# Altium schematic sheet IPM_Driver.SchDoc
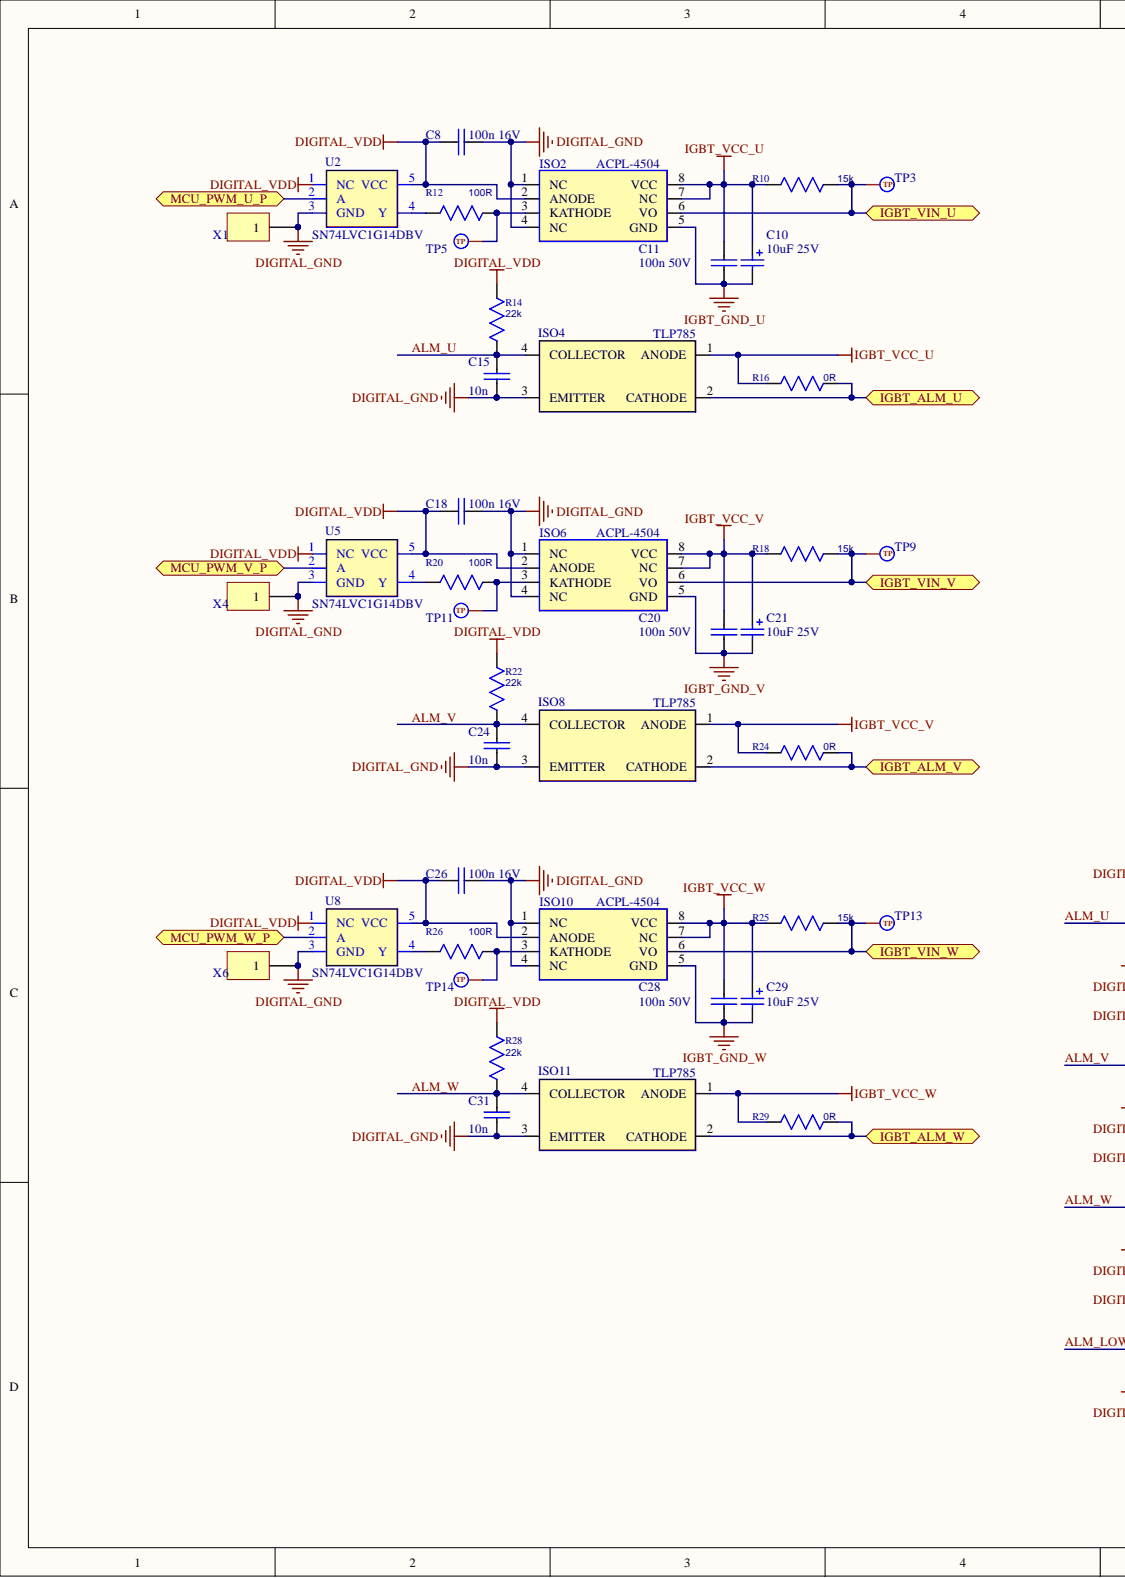
[diagram p0: IPM_Driver.SchDoc, left]
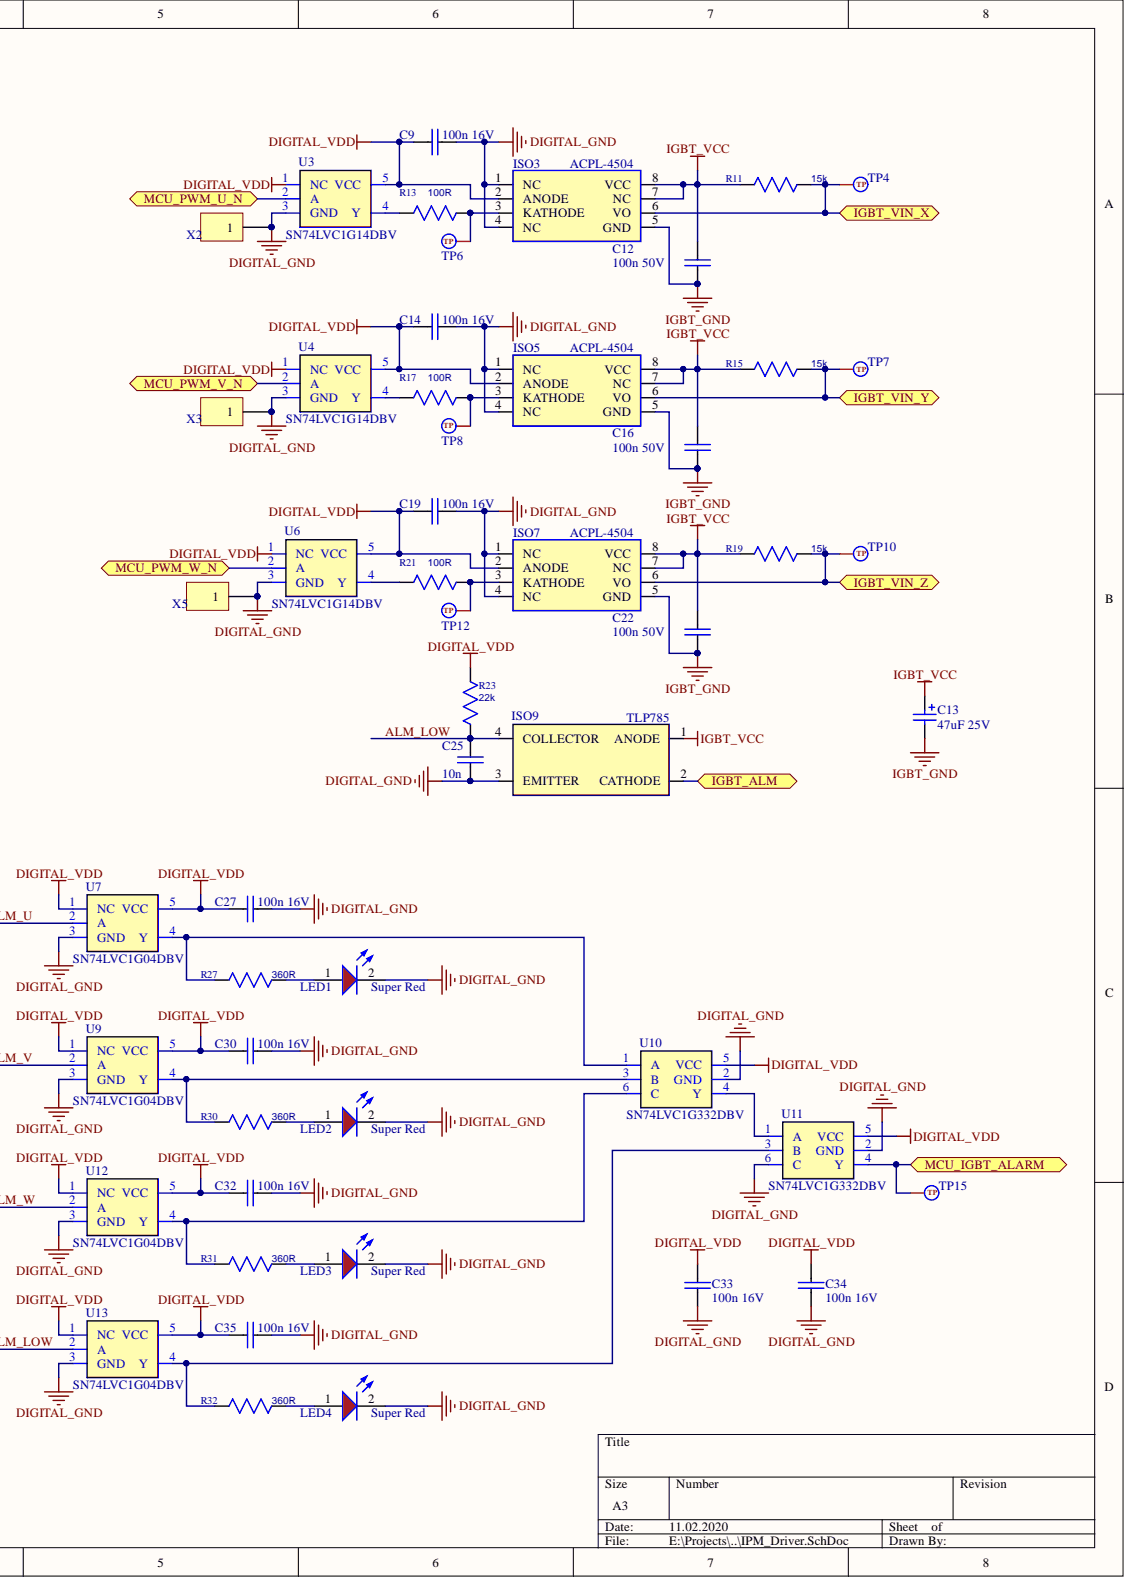
[diagram p1: IPM_Driver.SchDoc, right]

## Parts
C8  100n 16V  [C_0805]
C9  100n 16V  [C_0805]
C10  10uF 25V  [C]
C11  100n 50V  [C_0805]
C12  100n 50V  [C_0805]
C13  47uF 25V  [D]
C14  100n 16V  [C_0805]
C15  10n  [C_0805]
C16  100n 50V  [C_0805]
C18  100n 16V  [C_0805]
C19  100n 16V  [C_0805]
C20  100n 50V  [C_0805]
C21  10uF 25V  [C]
C22  100n 50V  [C_0805]
C24  10n  [C_0805]
C25  10n  [C_0805]
C26  100n 16V  [C_0805]
C27  100n 16V  [C_0805]
C28  100n 50V  [C_0805]
C29  10uF 25V  [C]
C30  100n 16V  [C_0805]
C31  10n  [C_0805]
C32  100n 16V  [C_0805]
C33  100n 16V  [C_0805]
C34  100n 16V  [C_0805]
C35  100n 16V  [C_0805]
ISO2  ACPL-4504  [DIP8-300]
ISO3  ACPL-4504  [DIP8-300]
ISO4  TLP785  [TLP785TP6]
ISO5  ACPL-4504  [DIP8-300]
ISO6  ACPL-4504  [DIP8-300]
ISO7  ACPL-4504  [DIP8-300]
ISO8  TLP785  [TLP785TP6]
ISO9  TLP785  [TLP785TP6]
ISO10  ACPL-4504  [DIP8-300]
ISO11  TLP785  [TLP785TP6]
LED1  Super Red  [LED_0805_Super Red]
LED2  Super Red  [LED_0805_Super Red]
LED3  Super Red  [LED_0805_Super Red]
LED4  Super Red  [LED_0805_Super Red]
R10  15k  [R_0805]
R11  15k  [R_0805]
R12  100R  [R_0805]
R13  100R  [R_0805]
R14  22k  [R_0805]
R15  15k  [R_0805]
R16  0R  [R_0805]
R17  100R  [R_0805]
R18  15k  [R_0805]
R19  15k  [R_0805]
R20  100R  [R_0805]
R21  100R  [R_0805]
R22  22k  [R_0805]
R23  22k  [R_0805]
R24  0R  [R_0805]
R25  15k  [R_0805]
R26  100R  [R_0805]
R27  360R  [R_0805]
R28  22k  [R_0805]
R29  0R  [R_0805]
R30  360R  [R_0805]
R31  360R  [R_0805]
R32  360R  [R_0805]
TP3  TEST_POINT  [TEST POINT D1.5]  (value not drawn)
TP4  TEST_POINT  [TEST POINT D1.5]  (value not drawn)
TP5  TEST_POINT  [TEST POINT D1.5]  (value not drawn)
TP6  TEST_POINT  [TEST POINT D1.5]  (value not drawn)
TP7  TEST_POINT  [TEST POINT D1.5]  (value not drawn)
TP8  TEST_POINT  [TEST POINT D1.5]  (value not drawn)
TP9  TEST_POINT  [TEST POINT D1.5]  (value not drawn)
TP10  TEST_POINT  [TEST POINT D1.5]  (value not drawn)
TP11  TEST_POINT  [TEST POINT D1.5]  (value not drawn)
TP12  TEST_POINT  [TEST POINT D1.5]  (value not drawn)
TP13  TEST_POINT  [TEST POINT D1.5]  (value not drawn)
TP14  TEST_POINT  [TEST POINT D1.5]  (value not drawn)
TP15  TEST_POINT  [TEST POINT D1.5]  (value not drawn)
U2  SN74LVC1G14DBV  [SOT23-5]
U3  SN74LVC1G14DBV  [SOT23-5]
U4  SN74LVC1G14DBV  [SOT23-5]
U5  SN74LVC1G14DBV  [SOT23-5]
U6  SN74LVC1G14DBV  [SOT23-5]
U7  SN74LVC1G04DBV  [SOT23-5]
U8  SN74LVC1G14DBV  [SOT23-5]
U9  SN74LVC1G04DBV  [SOT23-5]
U10  SN74LVC1G332DBV  [SOT23-6]
U11  SN74LVC1G332DBV  [SOT23-6]
U12  SN74LVC1G04DBV  [SOT23-5]
U13  SN74LVC1G04DBV  [SOT23-5]
X1  PLS-1  [PLS-1-3D]  (value not drawn)
X2  PLS-1  [PLS-1-3D]  (value not drawn)
X3  PLS-1  [PLS-1-3D]  (value not drawn)
X4  PLS-1  [PLS-1-3D]  (value not drawn)
X5  PLS-1  [PLS-1-3D]  (value not drawn)
X6  PLS-1  [PLS-1-3D]  (value not drawn)

## Ports
IGBT_ALM, IGBT_ALM_U, IGBT_ALM_V, IGBT_ALM_W, IGBT_VIN_U, IGBT_VIN_V, IGBT_VIN_W, IGBT_VIN_X, IGBT_VIN_Y, IGBT_VIN_Z, MCU_IGBT_ALARM, MCU_PWM_U_N, MCU_PWM_U_P, MCU_PWM_V_N, MCU_PWM_V_P, MCU_PWM_W_N, MCU_PWM_W_P

## Nets
DIGITAL_GND: C8.2 C9.2 C14.2 C15.2 C18.2 C19.2 C24.2 C25.2 C26.2 C27.2 C30.2 C31.2 C32.2 C33.1 C34.1 C35.2 ISO2.1(NC) ISO2.4(NC) ISO3.1(NC) ISO3.4(NC) ISO4.3(EMITTER) ISO5.1(NC) ISO5.4(NC) ISO6.1(NC) ISO6.4(NC) ISO7.1(NC) ISO7.4(NC) ISO8.3(EMITTER) ISO9.3(EMITTER) ISO10.1(NC) ISO10.4(NC) ISO11.3(EMITTER) LED1.2 LED2.2 LED3.2 LED4.2 U2.3(GND) U3.3(GND) U4.3(GND) U5.3(GND) U6.3(GND) U7.3(GND) U8.3(GND) U9.3(GND) U10.2(GND) U11.2(GND) U11.6(C) U12.3(GND) U13.3(GND) X1.1 X2.1 X3.1 X4.1 X5.1 X6.1
DIGITAL_VDD: C8.1 C9.1 C14.1 C18.1 C19.1 C26.1 C27.1 C30.1 C32.1 C33.2 C34.2 C35.1 ISO2.2(ANODE) ISO3.2(ANODE) ISO5.2(ANODE) ISO6.2(ANODE) ISO7.2(ANODE) ISO10.2(ANODE) R14.2 R22.2 R23.2 R28.2 U2.1(NC) U2.5(VCC) U3.1(NC) U3.5(VCC) U4.1(NC) U4.5(VCC) U5.1(NC) U5.5(VCC) U6.1(NC) U6.5(VCC) U7.1(NC) U7.5(VCC) U8.1(NC) U8.5(VCC) U9.1(NC) U9.5(VCC) U10.5(VCC) U11.5(VCC) U12.1(NC) U12.5(VCC) U13.1(NC) U13.5(VCC)
IGBT_GND: C12.2 C13.2 C16.2 C22.2 ISO3.5(GND) ISO5.5(GND) ISO7.5(GND)
IGBT_GND_U: C10.2 C11.2 ISO2.5(GND)
IGBT_GND_V: C20.2 C21.2 ISO6.5(GND)
IGBT_GND_W: C28.2 C29.2 ISO10.5(GND)
IGBT_VCC: C12.1 C13.1 C16.1 C22.1 ISO3.7(NC) ISO3.8(VCC) ISO5.7(NC) ISO5.8(VCC) ISO7.7(NC) ISO7.8(VCC) ISO9.1(ANODE) R11.1 R15.1 R19.1
IGBT_VCC_U: C10.1 C11.1 ISO2.7(NC) ISO2.8(VCC) ISO4.1(ANODE) R10.1 R16.2
IGBT_VCC_V: C20.1 C21.1 ISO6.7(NC) ISO6.8(VCC) ISO8.1(ANODE) R18.1 R24.2
IGBT_VCC_W: C28.1 C29.1 ISO10.7(NC) ISO10.8(VCC) ISO11.1(ANODE) R25.1 R29.2
ALM_LOW: C25.1 ISO9.4(COLLECTOR) R23.1 U13.2(A)
ALM_U: C15.1 ISO4.4(COLLECTOR) R14.1 U7.2(A)
ALM_V: C24.1 ISO8.4(COLLECTOR) R22.1 U9.2(A)
ALM_W: C31.1 ISO11.4(COLLECTOR) R28.1 U12.2(A)
IGBT_ALM: ISO9.2(CATHODE) ; port IGBT_ALM
IGBT_ALM_U: ISO4.2(CATHODE) R16.1 ; port IGBT_ALM_U
IGBT_ALM_V: ISO8.2(CATHODE) R24.1 ; port IGBT_ALM_V
IGBT_ALM_W: ISO11.2(CATHODE) R29.1 ; port IGBT_ALM_W
IGBT_VIN_U: ISO2.6(VO) R10.2 TP3.1 ; port IGBT_VIN_U
IGBT_VIN_V: ISO6.6(VO) R18.2 TP9.1 ; port IGBT_VIN_V
IGBT_VIN_W: ISO10.6(VO) R25.2 TP13.1 ; port IGBT_VIN_W
IGBT_VIN_X: ISO3.6(VO) R11.2 TP4.1 ; port IGBT_VIN_X
IGBT_VIN_Y: ISO5.6(VO) R15.2 TP7.1 ; port IGBT_VIN_Y
IGBT_VIN_Z: ISO7.6(VO) R19.2 TP10.1 ; port IGBT_VIN_Z
MCU_IGBT_ALARM: TP15.1 U11.4(Y) ; port MCU_IGBT_ALARM
MCU_PWM_U_N: U3.2(A) ; port MCU_PWM_U_N
MCU_PWM_U_P: U2.2(A) ; port MCU_PWM_U_P
MCU_PWM_V_N: U4.2(A) ; port MCU_PWM_V_N
MCU_PWM_V_P: U5.2(A) ; port MCU_PWM_V_P
MCU_PWM_W_N: U6.2(A) ; port MCU_PWM_W_N
MCU_PWM_W_P: U8.2(A) ; port MCU_PWM_W_P
NetISO2_3: ISO2.3(KATHODE) R12.2 TP5.1
NetISO3_3: ISO3.3(KATHODE) R13.2 TP6.1
NetISO5_3: ISO5.3(KATHODE) R17.2 TP8.1
NetISO6_3: ISO6.3(KATHODE) R20.2 TP11.1
NetISO7_3: ISO7.3(KATHODE) R21.2 TP12.1
NetISO10_3: ISO10.3(KATHODE) R26.2 TP14.1
NetLED1_1: LED1.1 R27.1
NetLED2_1: LED2.1 R30.1
NetLED3_1: LED3.1 R31.1
NetLED4_1: LED4.1 R32.1
NetR12_1: R12.1 U2.4(Y)
NetR13_1: R13.1 U3.4(Y)
NetR17_1: R17.1 U4.4(Y)
NetR20_1: R20.1 U5.4(Y)
NetR21_1: R21.1 U6.4(Y)
NetR26_1: R26.1 U8.4(Y)
NetR27_2: R27.2 U7.4(Y) U10.1(A)
NetR30_2: R30.2 U9.4(Y) U10.3(B)
NetR31_2: R31.2 U10.6(C) U12.4(Y)
NetR32_2: R32.2 U11.3(B) U13.4(Y)
NetU10_4: U10.4(Y) U11.1(A)

## Library data of the parts
C8 C9 C11 C12 C14-C16 C18-C20 C22 C24-C28 C30-C35 = Cap NP: CERAMIC CAPACITOR; Component Kind: Standard; Library Name: Capacitors.SchLib
  C8 C9 C14 C18 C19 C26 C27 C30 C32-C35: Value: 100n 16V
  C11 C12 C16 C20 C22 C28: Value: 100n 50V
  C15 C24 C25 C31: Value: 10n
C10 C21 C29 = T491C: Solid Tantalum Chip Capacitor, Standard T491 Series - Industrial Grade; Code_JEITA: 6032-28; Component Kind: Standard; ComponentLink1Description: Manufacturer Link; ComponentLink1URL: http://www.kemet.com/; ComponentLink2Description: Datasheet; ComponentLink2URL: http://www.kemet.com/kemet/web/homepage/kechome.nsf/vapubfilesname/F3102TA.pdf/$file/F3102TA.pdf; DatasheetDocument: Mar-2001; LatestRevisionDate: 19-Mar-2004; LatestRevisionNote: Silkscreen dimensions re-sized.; Library Name: Capacitors.SchLib; PackageDocument: Mar-2001; PackageReference: C; Published: 17-Jul-2002; Signal Integrity: T491C; Simulation: CAP; Value: 10uF 25V; Publisher: Altium Limited
C13 = T491D: Solid Tantalum Chip Capacitor, Standard T491 Series - Industrial Grade; Code_JEITA: 7343-31; Component Kind: Standard; ComponentLink1Description: Manufacturer Link; ComponentLink1URL: http://www.kemet.com/; ComponentLink2Description: Datasheet; ComponentLink2URL: http://www.kemet.com/kemet/web/homepage/kechome.nsf/vapubfilesname/F3102TA.pdf/$file/F3102TA.pdf; DatasheetDocument: Mar-2001; LatestRevisionDate: 19-Mar-2004; LatestRevisionNote: Silkscreen dimensions re-sized.; Library Name: Capacitors.SchLib; PackageDocument: Mar-2001; PackageReference: D; Published: 17-Jul-2002; Signal Integrity: T491D; Simulation: CAP; Value: 47uF 25V; Publisher: Altium Limited
ISO2 ISO3 ISO5-ISO7 ISO10 = ACPL-4504: High CMR, High Speed Optocouplers; Component Kind: Standard; Text: ACPL-4504; Library Name: Isolator.SchLib
ISO4 ISO8 ISO9 ISO11 = TLP785(GR-TP6,F): Photocouplers Infrared Led; Component Kind: Standard; Value: TLP785; Library Name: Isolator.SchLib
LED1-LED4 = WL-SMCW 0805  150080SS75000: SMD mono-color Chip LED, WL-SMCW, Super Red; Color: Super Red; Component Kind: Standard; Library Name: Leds.SchLib
R10-R32 = R0805: Resistor; Component Kind: Standard; Library Name: Resistors.SchLib
  R10 R11 R15 R18 R19 R25: Value: 15k
  R12 R13 R17 R20 R21 R26: Value: 100R
  R14 R22 R23 R28: Value: 22k
  R16 R24 R29: Value: 0R
  R27 R30-R32: Value: 360R
TP3-TP15 = TEST_POINT: Signal Test Point; Component Kind: Standard; Library Name: Discrete.SchLib
U2-U6 U8 = SN74LVC1G14DBV: Syngle Inverter Schmitt Gate SOT23-5; Component Kind: Standard; Value: SN74LVC1G14DBV; Library Name: Gates.SchLib
U7 U9 U12 U13 = SN74LVC1G04DBV: Syngle Inverter Gate SOT23-5; Component Kind: Standard; Value: SN74LVC1G04DBV; Library Name: Gates.SchLib
U10 U11 = SN74LVC1G332DBV: Single 3-Input Positive-OR Gate; Component Kind: Standard; Value: SN74LVC1G332DBV; Library Name: Gates.SchLib
X1-X6 = PLS-1: Header, 1-Pin; Component Kind: Standard; LatestRevisionDate: 17-Jul-2002; LatestRevisionNote: Re-released for DXP Platform.; Library Name: Connectors.SchLib; Publisher: Altium Limited
